# Altium schematic sheet 02_MCU_Connector.SchDoc
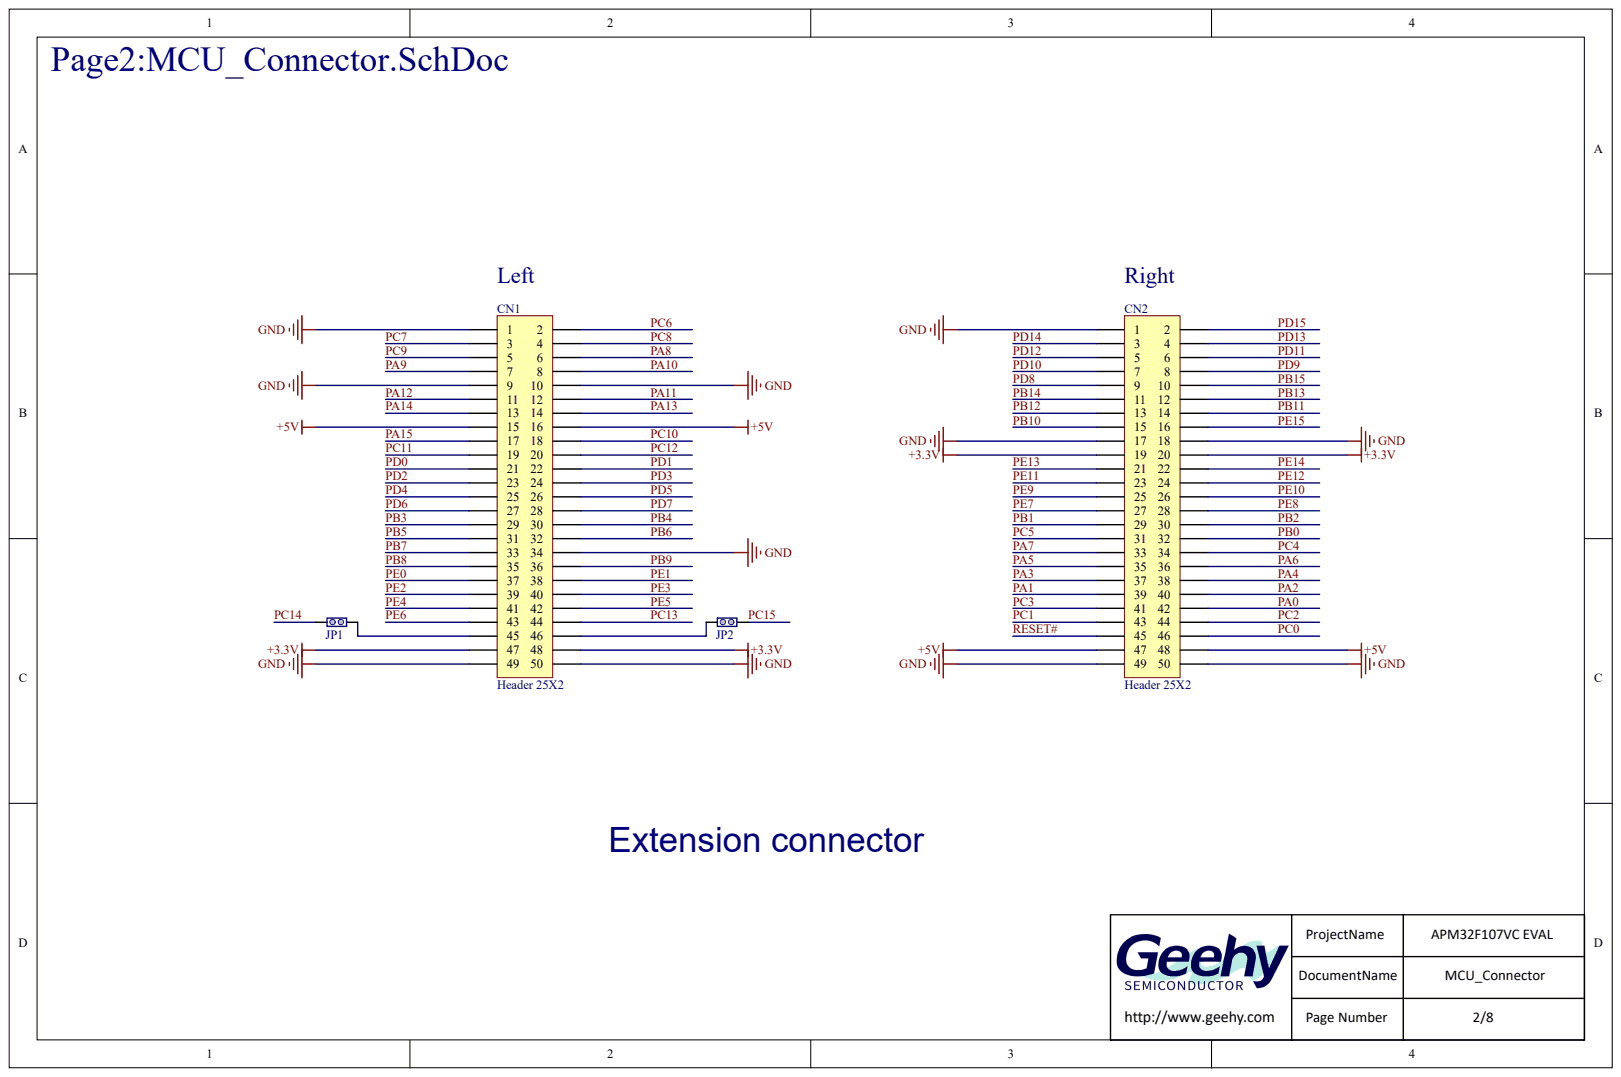
[diagram p0: 02_MCU_Connector.SchDoc]

## Parts
CN1  Header 25X2
CN2  Header 25X2
JP1  (no value)
JP2  (no value)

## Nets
+3.3V: CN1.47 CN1.48 CN2.19 CN2.20
+5V: CN1.15 CN1.16 CN2.47 CN2.48
GND: CN1.1 CN1.9 CN1.10 CN1.34 CN1.49 CN1.50 CN2.1 CN2.17 CN2.18 CN2.49 CN2.50
PA0: CN2.42
PA1: CN2.39
PA2: CN2.40
PA3: CN2.37
PA4: CN2.38
PA5: CN2.35
PA6: CN2.36
PA7: CN2.33
PA8: CN1.6
PA9: CN1.7
PA10: CN1.8
PA11: CN1.12
PA12: CN1.11
PA13: CN1.14
PA14: CN1.13
PA15: CN1.17
PB0: CN2.32
PB1: CN2.29
PB2: CN2.30
PB3: CN1.29
PB4: CN1.30
PB5: CN1.31
PB6: CN1.32
PB7: CN1.33
PB8: CN1.35
PB9: CN1.36
PB10: CN2.15
PB11: CN2.14
PB12: CN2.13
PB13: CN2.12
PB14: CN2.11
PB15: CN2.10
PC0: CN2.46
PC1: CN2.43
PC2: CN2.44
PC3: CN2.41
PC4: CN2.34
PC5: CN2.31
PC6: CN1.2
PC7: CN1.3
PC8: CN1.4
PC9: CN1.5
PC10: CN1.18
PC11: CN1.19
PC12: CN1.20
PC13: CN1.44
PC14: JP1.1
PC15: JP2.1
PD0: CN1.21
PD1: CN1.22
PD2: CN1.23
PD3: CN1.24
PD4: CN1.25
PD5: CN1.26
PD6: CN1.27
PD7: CN1.28
PD8: CN2.9
PD9: CN2.8
PD10: CN2.7
PD11: CN2.6
PD12: CN2.5
PD13: CN2.4
PD14: CN2.3
PD15: CN2.2
PE0: CN1.37
PE1: CN1.38
PE2: CN1.39
PE3: CN1.40
PE4: CN1.41
PE5: CN1.42
PE6: CN1.43
PE7: CN2.27
PE8: CN2.28
PE9: CN2.25
PE10: CN2.26
PE11: CN2.23
PE12: CN2.24
PE13: CN2.21
PE14: CN2.22
PE15: CN2.16
RESET#: CN2.45
NetCN1_45: CN1.45 JP1.2
NetCN1_46: CN1.46 JP2.2

## Library data of the parts
CN1 CN2 JP1 JP2 = (no symbol name)
  CN1 CN2: Revision: 17-Jul-2002: Re-released for DXP Platform.; Publisher: Altium Limited
